# Altium schematic sheet power.SchDoc(power)
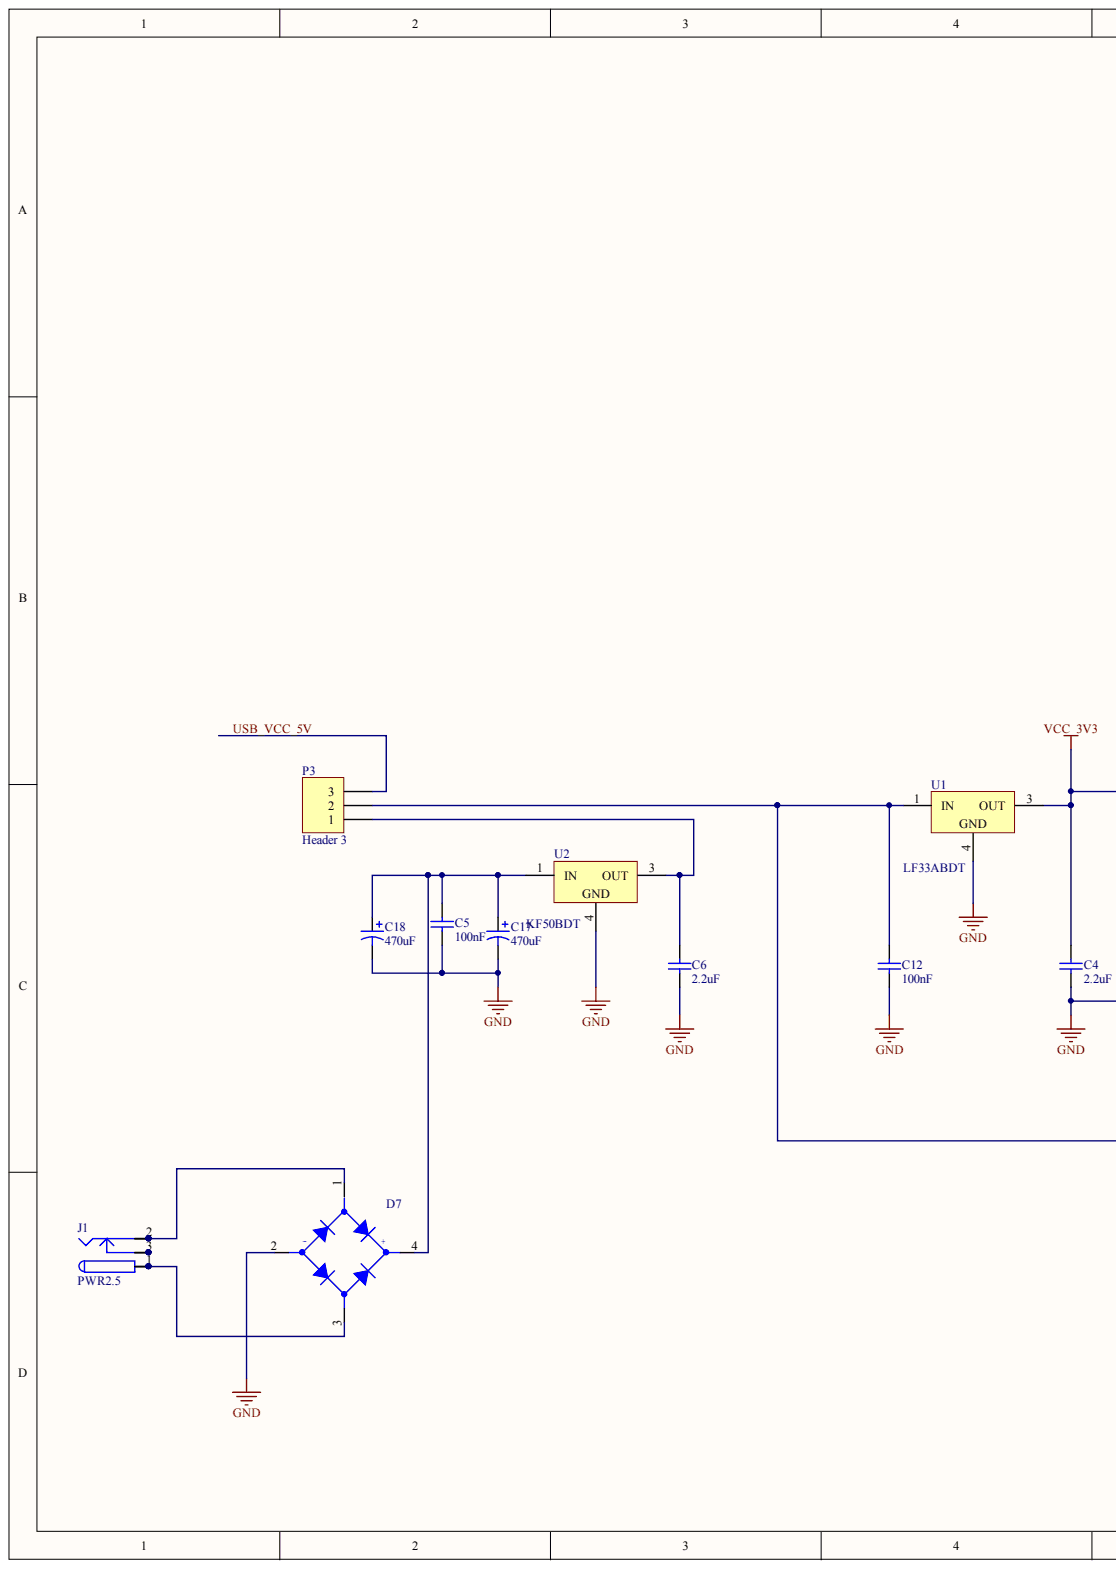
[diagram p0: power.SchDoc, left]
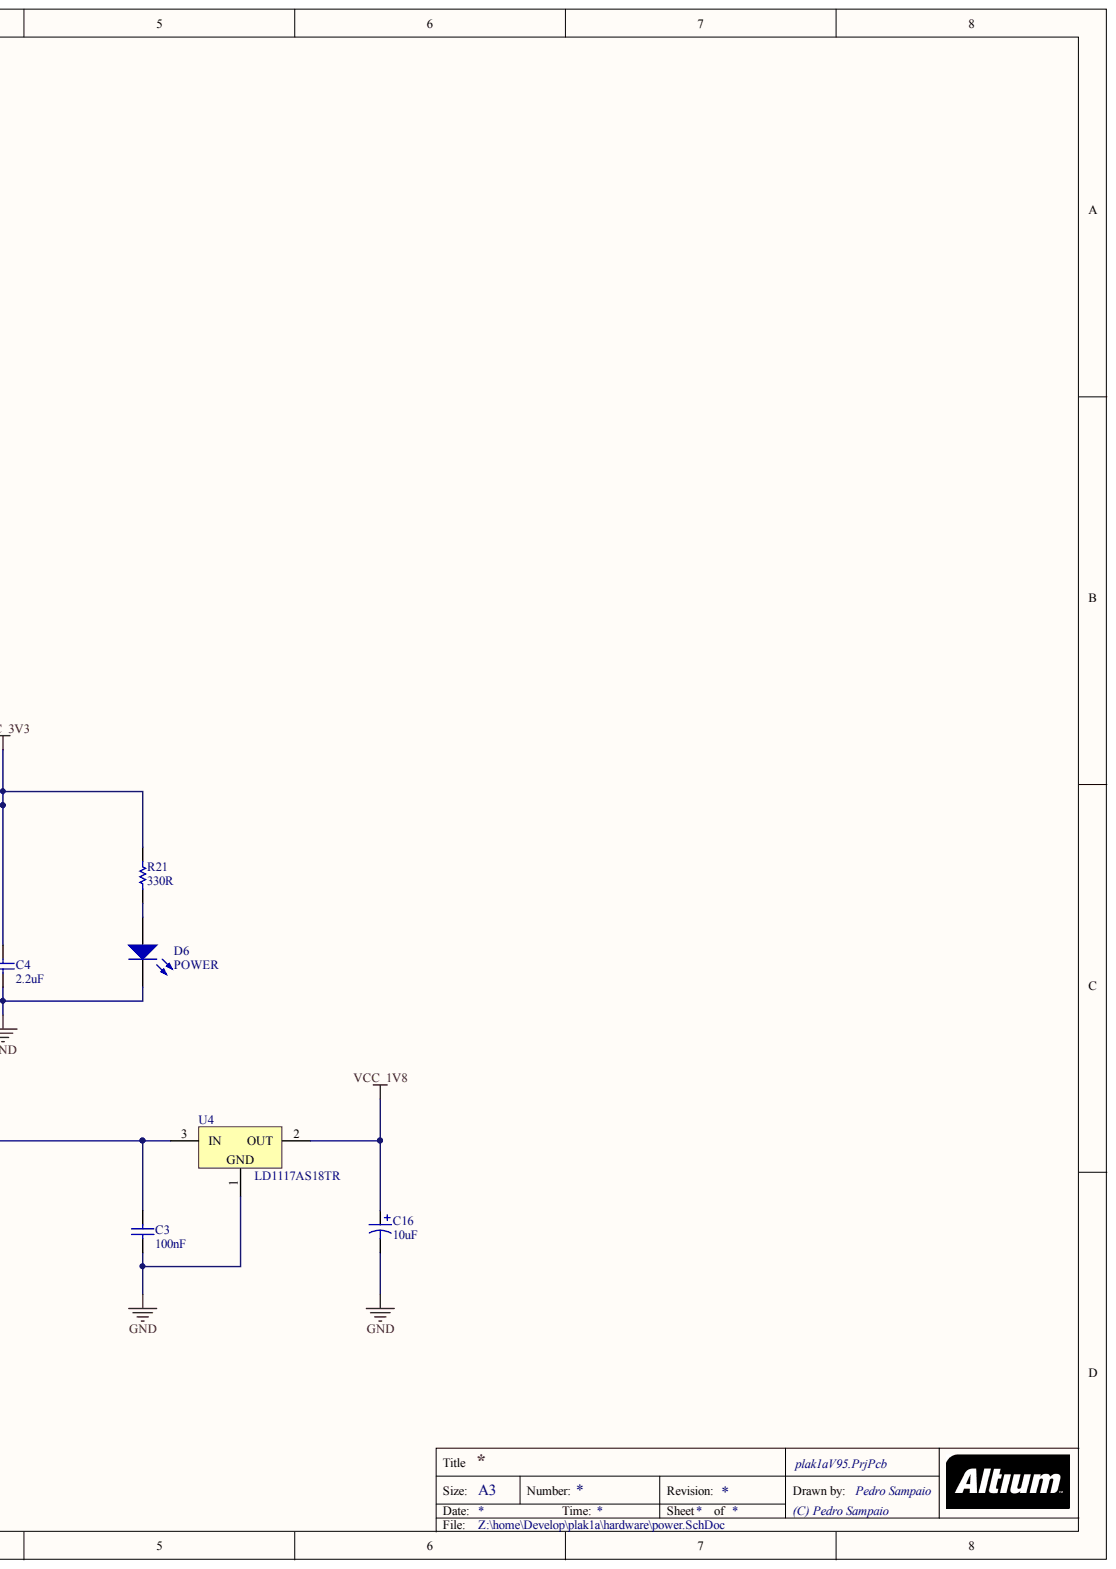
[diagram p1: power.SchDoc, right]

## Parts
C3  value 100nF  [0603]  (hidden comment: C0603)
C4  value 2.2uF  [1608[0603]]  (hidden comment: 9402101)
C5  value 100nF  [0603]  (hidden comment: C0603)
C6  value 2.2uF  [1608[0603]]  (hidden comment: 9402101)
C12  value 100nF  [0603]  (hidden comment: C0603)
C16  value 10uF  [B]  (hidden comment: T491B)
C17  value 470uF  [C]  (hidden comment: T491C)
C18  value 470uF  [C]  (hidden comment: T491C)
D6  POWER  [1.6X0.8X0.4]
D7  Bridge Rectifier  [DIL.4]  (value not drawn)
J1  PWR2.5  [KLD-0202]
P3  Header 3  [HDR1X3]
R21  value 330R  [3216[1206]]  (hidden comment: Res Semi)
U1  LF33ABDT  [TO-252]
U2  KF50BDT  [TO-252]
U4  LD1117AS18TR  [SOT223]

## Nets
GND: C3.1 C4.1 C5.1 C6.1 C12.1 C16.2 C17.2 C18.2 D6.2 D7.2 U1.4 U2.4 U4.1
VCC_1V8: C16.1 U4.2
VCC_3V3: C4.2 R21.2 U1.3
USB_VCC_5V: P3.3
NetC3_2: C3.2 C12.2 P3.2 U1.1 U4.3
NetC5_2: C5.2 C17.1 C18.1 D7.4 U2.1
NetC6_2: C6.2 P3.1 U2.3
NetD6_1: D6.1 R21.1
NetD7_1: D7.1 J1.2 J1.2A J1.2B
NetD7_3: D7.3 J1.1 J1.1A J1.1B
NetJ1_3: J1.3 J1.3A J1.3B

## Library data of the parts
C3-C6 C12 = C0603: Ceramic Chip Capacitor - Standard; Note: Footprint corresponds to dimensions for bulk cassette packaging. This case size has tightened tolerances.; Code_IPC: 1608 [0603]; Code_JEITA: 0603; Component Kind: Standard; ComponentLink1Description: Manufacturer Link; ComponentLink1URL: http://www.kemet.com/; ComponentLink2Description: Datasheet; ComponentLink2URL: http://www.kemet.com/kemet/web/homepage/kechome.nsf/vapubfilesname/F3102TA.pdf/$file/F3102TA.pdf; DatasheetDocument: Mar-2001; LatestRevisionDate: 19-Mar-2004; LatestRevisionNote: Silkscreen size reduced.; Library Name: KEMET Chip Capacitor.IntLib; PackageDocument: Mar-2001; PackageReference: 0603; Published: 17-Jul-2002; Signal Integrity: C0603; Simulation: CAP; Publisher: Altium Limited
  C3 C5 C12: Farnell: 1301716
  C4 C6: Farnell: 1327728
C16 = T491B: Solid Tantalum Chip Capacitor, Standard T491 Series - Industrial Grade; Code_JEITA: 3528-21; Component Kind: Standard; ComponentLink1Description: Manufacturer Link; ComponentLink1URL: http://www.kemet.com/; ComponentLink2Description: Datasheet; ComponentLink2URL: http://www.kemet.com/kemet/web/homepage/kechome.nsf/vapubfilesname/F3102TA.pdf/$file/F3102TA.pdf; DatasheetDocument: Mar-2001; Farnell: 1301818; LatestRevisionDate: 19-Mar-2004; LatestRevisionNote: Silkscreen dimensions re-sized.; Library Name: KEMET Chip Capacitor.IntLib; PackageDocument: Mar-2001; PackageReference: B; Published: 17-Jul-2002; Signal Integrity: T491B; Simulation: CAP; Publisher: Altium Limited
C17 C18 = T491C: Solid Tantalum Chip Capacitor, Standard T491 Series - Industrial Grade; Code_JEITA: 6032-28; Component Kind: Standard; ComponentLink1Description: Manufacturer Link; ComponentLink1URL: http://www.kemet.com/; ComponentLink2Description: Datasheet; ComponentLink2URL: http://www.kemet.com/kemet/web/homepage/kechome.nsf/vapubfilesname/F3102TA.pdf/$file/F3102TA.pdf; DatasheetDocument: Mar-2001; Farnell: 1432384; LatestRevisionDate: 19-Mar-2004; LatestRevisionNote: Silkscreen dimensions re-sized.; Library Name: KEMET Chip Capacitor.IntLib; PackageDocument: Mar-2001; PackageReference: C; Published: 17-Jul-2002; Signal Integrity: T491C; Simulation: CAP; Publisher: Altium Limited
D6 = HSMT-C197: Low Profile Chip LED, Red; LuminousIntensity: 15mcd (Minimum).; PCB_Layout: Complies with manufacturer's recommendation.; Component Kind: Standard; ComponentLink1Description: Manufacturer Link; ComponentLink1URL: http://www.agilent.com/; ComponentLink2Description: Datasheet; ComponentLink2URL: http://cp.literature.agilent.com/litweb/pdf/5988-5501EN.pdf; DatasheetDocument: 27-Jan-2002; LED_Colour: Red; Library Name: Agilent Optoelectronic LED.IntLib; PackageDocument: 27-Jan-2002; PackageReference: 1.6X0.8X0.4; Published: 11-Dec-2002; Signal Integrity: LED; Simulation: Agl_HSMCC177; Publisher: Altium Limited
J1 = PWR2.5: Low Voltage Power Supply Connector; Component Kind: Standard; ComponentLink1Description: Manufacturer Link; ComponentLink1URL: http://domino.kycon.com/internet/Products.html; DatasheetDocument: 09-May-2002; Library Name: Miscellaneous Connectors.IntLib; Published: 17-Dec-2003; Signal Integrity: Connector; Publisher: Altium Limited
P3 = Header 3: Header, 3-Pin; Component Kind: Standard; LatestRevisionDate: 17-Jul-2002; LatestRevisionNote: Re-released for DXP Platform.; Library Name: Miscellaneous Connectors.IntLib; Signal Integrity: Connector; Publisher: Altium Limited
R21 = Res Semi: Semiconductor Resistor; Component Kind: Standard; Farnell: 1527413; LatestRevisionDate: 17-Jul-2002; LatestRevisionNote: Re-released for DXP Platform.; Library Name: Miscellaneous Devices.IntLib; PackageReference: AXIAL-0.5; Published: 8-Jun-2000; Signal Integrity: Res Semi; Simulation: RES; Publisher: Altium Limited
U1 = LF33ABDT: 500mA, Very Low Drop (0.45V) Voltage Regulator; Component Kind: Standard; ComponentLink1Description: Manufacturer Link; ComponentLink1URL: http://www.st.com/; ComponentLink2Description: Datasheet; ComponentLink2URL: http://www.st.com/stonline/books/pdf/docs/2574.pdf; DatasheetVersion: Feb-2004; Farnell: 1087183; LatestRevisionDate: 06-Jun-2005; LatestRevisionNote: PCB pads lengthened.; Library Name: ST Power Mgt Voltage Regulator.IntLib; PackageDescription: D-PAK/TO-252-AA; 3 Leads (1 Cropped); Body 10.1 (inc. leads) x 6.6 mm (LxW max); PackageReference: TO-252; PackageVersion: Jun-2004; Published: 24-Aug-2001; Publisher: Altium Limited
U2 = KF50BDT: 500mA, Very Low Drop (0.4V) Voltage Regulator; Component Kind: Standard; ComponentLink1Description: Manufacturer Link; ComponentLink1URL: http://www.st.com/; ComponentLink2Description: Datasheet; ComponentLink2URL: http://www.st.com/stonline/products/literature/ds/4337/kf120b.pdf; DatasheetVersion: Jun-1998; Farnell: 1467757; LatestRevisionDate: 06-Jun-2005; LatestRevisionNote: PCB pads lengthened.; Library Name: ST Power Mgt Voltage Regulator.IntLib; PackageDescription: D-PAK/TO-252-AA; 3 Leads (1 Cropped); Body 10.1 (inc. leads) x 6.6 mm (LxW max); PackageReference: TO-252; PackageVersion: Jun-2004; Published: 24-Aug-2001; Publisher: Altium Limited
U4 = L7952ACV: ± 2%Negative Voltage Regulator; Note: Tab is connected to pin 3 (IN).; Component Kind: Standard; ComponentLink1Description: Manufacturer Link; ComponentLink1URL: http://www.st.com/; DatasheetVersion: Jan-1993; Farnell: 1467775; LatestRevisionDate: 17-Jul-2002; LatestRevisionNote: Re-released for DXP Platform.; Library Name: ST Power Mgt Voltage Regulator.IntLib; PackageDescription: TO, Thru-Hole, Vertical, Heatsink Mounted; 3 In-Line Leads; Pitch 2.54 mm; Pin sequence 1, 3, 2; PackageReference: TO220V; Published: 24-Aug-2001; Publisher: Altium Limited
D7 = Bridge Rectifier: Component Kind: Standard; Library Name: Componentes.IntLib
